# Altium schematic sheet GDLink.SchDoc(GDLink)
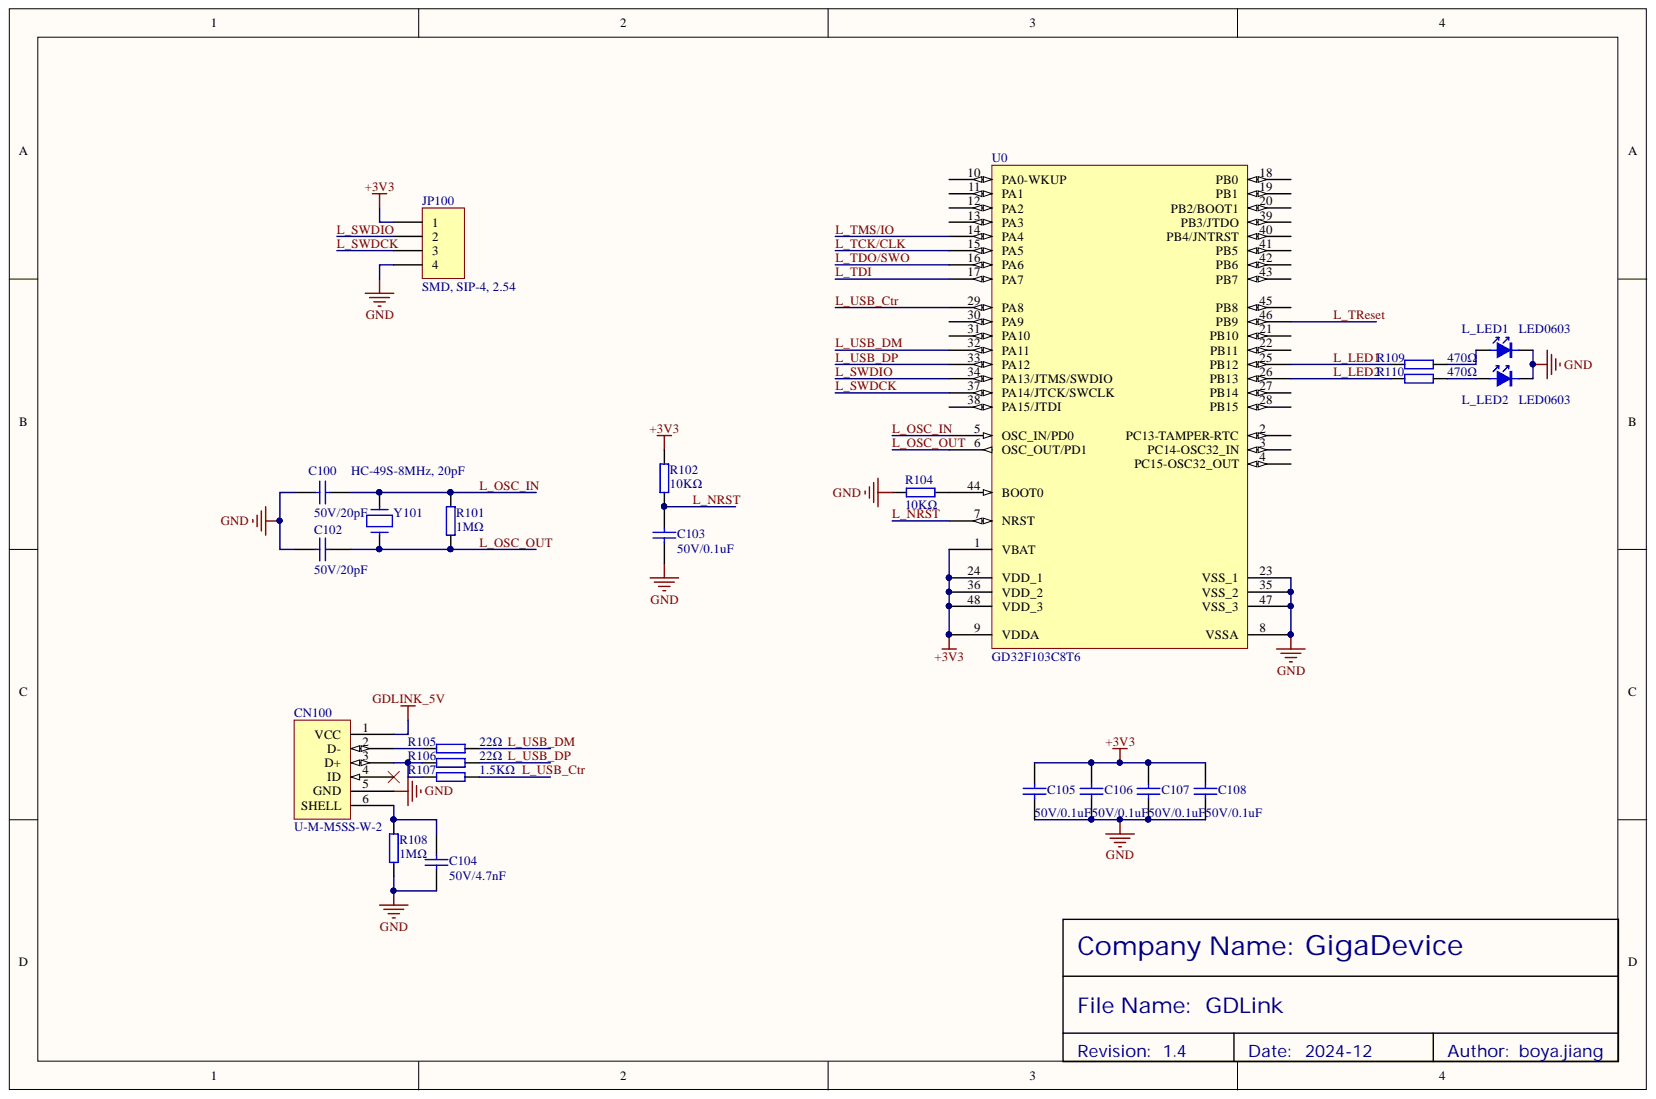
[diagram p0: GDLink.SchDoc]

## Parts
C100  50V/20pF  [0603-C]
C102  50V/20pF  [0603-C]
C103  50V/0.1uF  [0603-C]
C104  50V/4.7nF  [0603-C]
C105  50V/0.1uF  [0603-C]
C106  50V/0.1uF  [0603-C]
C107  50V/0.1uF  [0603-C]
C108  50V/0.1uF  [0603-C]
CN100  U-M-M5SS-W-2  [USB_MINI]
JP100  SMD, SIP-4, 2.54  [SMD-SWD]
L_LED1  LED0603  [0603-LED-Green]
L_LED2  LED0603  [0603-LED-Green]
R101  1MΩ  [0603-R]
R102  10KΩ  [0603-R]
R104  10KΩ  [0603-R]
R105  22Ω  [0603-R]
R106  22Ω  [0603-R]
R107  1.5KΩ  [0603-R]
R108  1MΩ  [0603-R]
R109  470Ω  [0603-R]
R110  470Ω  [0603-R]
U0  GD32F103C8T6  [LQFP48_7x7x1.6]
Y101  HC-49S-8MHz, 20pF  [HC-49S]

## Nets
+3V3: C105.2 C106.2 C107.2 C108.2 JP100.1 R102.2 U0.1(VBAT) U0.9(VDDA) U0.24(VDD_1) U0.36(VDD_2) U0.48(VDD_3)
GDLINK_5V: CN100.1(VCC)
GND: C100.1 C102.2 C103.2 C104.1 C105.1 C106.1 C107.1 C108.1 CN100.5(GND) JP100.4 L_LED1.K L_LED2.K R104.1 R108.1 U0.8(VSSA) U0.23(VSS_1) U0.35(VSS_2) U0.47(VSS_3)
L_LED1: R109.1 U0.25(PB12)
L_LED2: R110.1 U0.26(PB13)
L_NRST: C103.1 R102.1 U0.7(NRST)
L_OSC_IN: C100.2 R101.2 U0.5(OSC_IN/PD0) Y101.2
L_OSC_OUT: C102.1 R101.1 U0.6(OSC_OUT/PD1) Y101.1
L_SWDCK: JP100.3 U0.37(PA14/JTCK/SWCLK)
L_SWDIO: JP100.2 U0.34(PA13/JTMS/SWDIO)
L_TCK/CLK: U0.15(PA5)
L_TDI: U0.17(PA7)
L_TDO/SWO: U0.16(PA6)
L_TMS/IO: U0.14(PA4)
L_TReset: U0.46(PB9)
L_USB_Ctr: R107.1 U0.29(PA8)
L_USB_DM: R105.1 U0.32(PA11)
L_USB_DP: R106.1 U0.33(PA12)
NetC104_2: C104.2 CN100.6(SHELL) R108.2
NetCN100_2: CN100.2(D-) R105.2
NetCN100_3: CN100.3(D+) R106.2 R107.2
NetL_LED1_A: L_LED1.A R109.2
NetL_LED2_A: L_LED2.A R110.2
NetR104_2: R104.2 U0.44(BOOT0)

Not connected: CN100 4(ID); U0 2(PC13-TAMPER-RTC) 3(PC14-OSC32_IN) 4(PC15-OSC32_OUT) 10(PA0-WKUP) 11(PA1) 12(PA2) 13(PA3) 18(PB0) 19(PB1) 20(PB2/BOOT1) 21(PB10) 22(PB11) 27(PB14) 28(PB15) 30(PA9) 31(PA10) 38(PA15/JTDI) 39(PB3/JTDO) 40(PB4/JNTRST) 41(PB5) 42(PB6) 43(PB7) 45(PB8)

## Library data of the parts
C100 C102 = 50V/20pF: 表贴电容; Component Kind: Standard; Library Name: KLTC-电容.SchLib
C103 C105-C108 = 50V/0.1uF: 表贴电容; Component Kind: Standard; Library Name: KLTC-电容.SchLib
C104 = 50V/4700pF: 表贴电容; Component Kind: Standard; Library Name: KLTC-电容.SchLib
CN100 = USB-MINI-typeB connector: 表贴miniusb座; Order: 5075BMR-05-SM; Manuf_Part_1: 5075BMR-05-SM; Library Name: MCD_TOOLS.SchLib; ECCN: ????; Component Kind: Standard
JP100 = HEADER 4: 表贴排针; Component Kind: Standard; Library Name: KLTC-按键及接插件.SchLib
L_LED1 L_LED2 = LED0603: 表贴LED发光管; Component Kind: Standard; Library Name: xufei.SchLib
R101 R108 = 1MΩ: 表贴电阻; Component Kind: Standard; Library Name: KLTC-电阻.SchLib
R102 R104 = 10KΩ: 表贴电阻; Component Kind: Standard; Library Name: KLTC-电阻.SchLib
R105 R106 R109 R110 = 1KΩ: 表贴电阻; Component Kind: Standard; Library Name: KLTC-电阻.SchLib
R107 = 1.5KΩ: 表贴电阻; Component Kind: Standard; Library Name: KLTC-电阻.SchLib
U0 = GD32F103CBT6: 表贴MCU; Library Name: GD32MCU.SchLib; Component Kind: Standard
Y101 = HC-49S-G20SSA-8MHz: 直插晶振; Component Kind: Standard; Library Name: KLTC-晶振.SchLib
